# Altium schematic sheet hcsr04.SchDoc
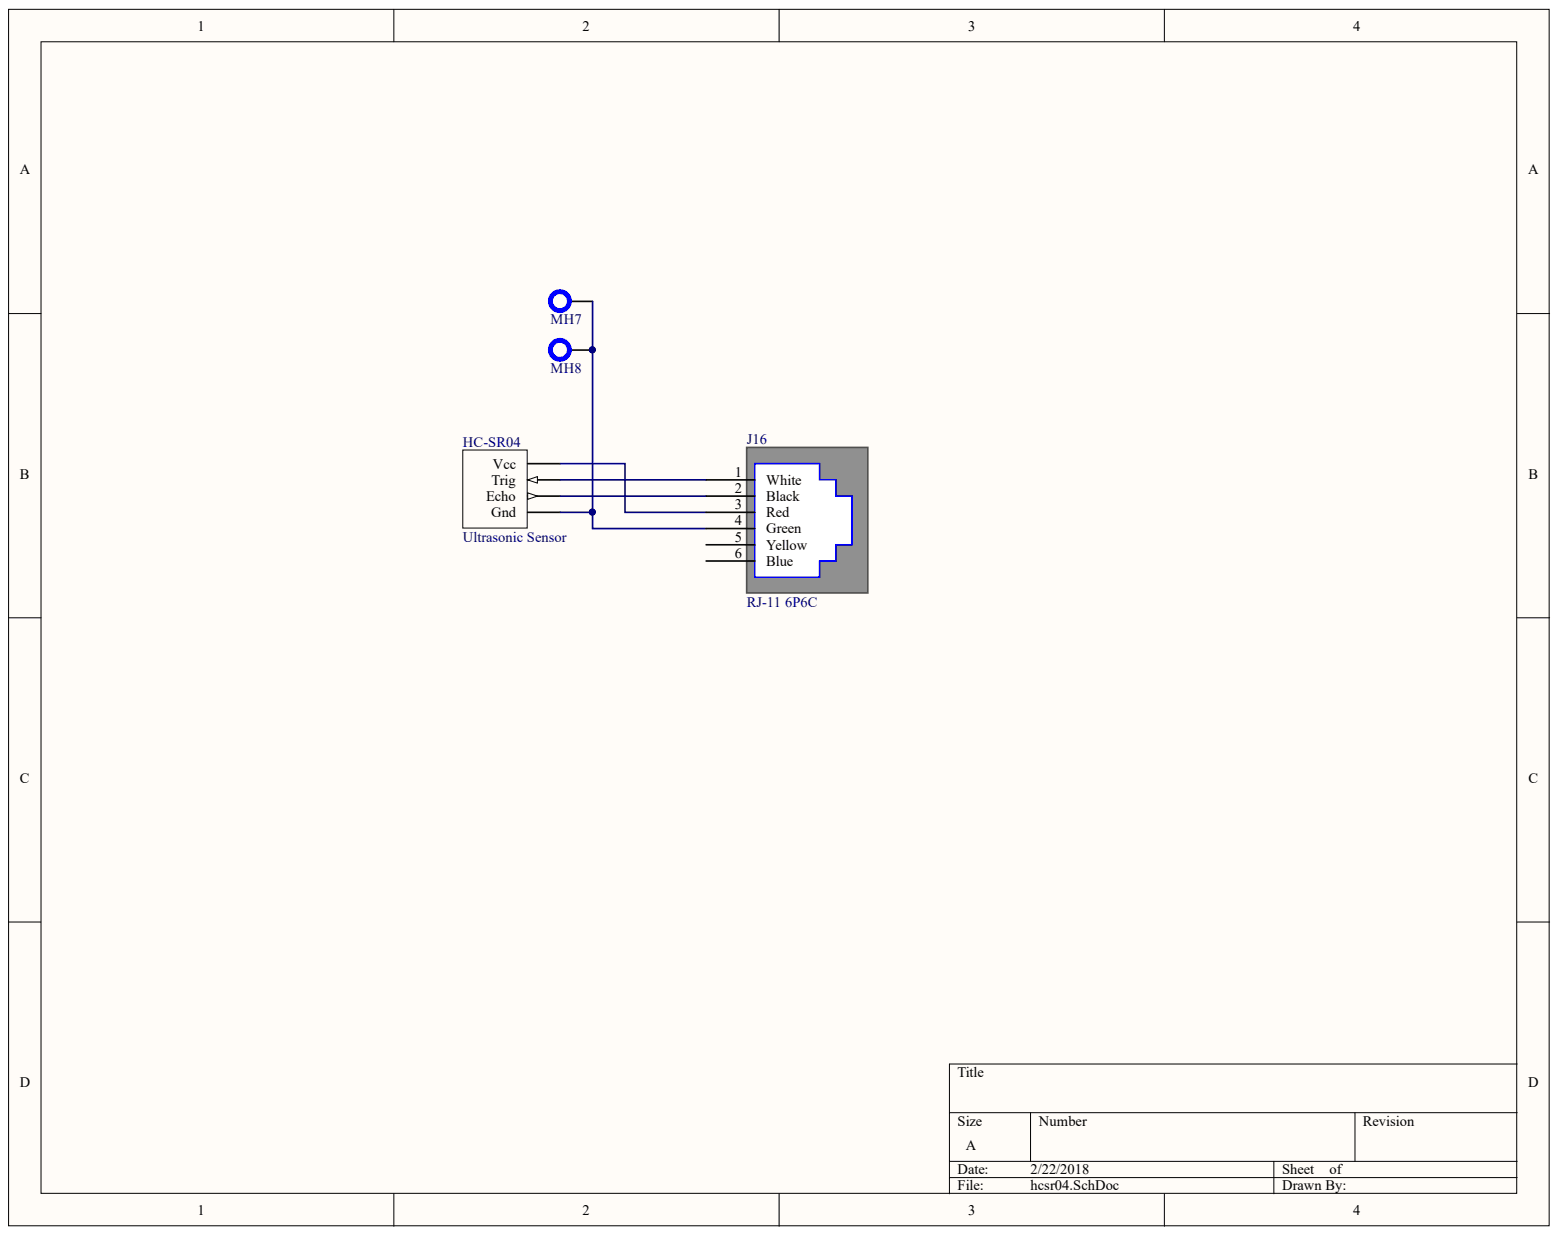
[diagram p0: hcsr04.SchDoc]

## Parts
HC-SR04  Ultrasonic Sensor  [PCB-mx1y8omaqth4jr3a8ds5-1]
J16  RJ-11 6P6C  [PCB-dhj0y0hoiu0232y63q4o-1]
MH7  MountHole  [PCB-d0jqlyxt4wqhvgbnry4h-1]  (value not drawn)
MH8  MountHole  [PCB-d0jqlyxt4wqhvgbnry4h-1]  (value not drawn)

## Nets
NetHC-SR04_1: HC-SR04.1(Vcc) J16.3(Red)
NetHC-SR04_2: HC-SR04.2(Trig) J16.1(White)
NetHC-SR04_3: HC-SR04.3(Echo) J16.2(Black)
NetHC-SR04_4: HC-SR04.4(Gnd) J16.4(Green) MH7.1 MH8.1

Not connected: J16 5(Yellow) 6(Blue)

## Library data of the parts
HC-SR04 = CMP-75f1bf27582daa36-6: Ultrasonic Sensor Module; Component Kind: Standard; Library Name: CommunityVault; OctopartID: 75f1bf27582daa36
J16 = CMP-33ecfa0a2b0dec2b-2: Conn RJ-11 F 6 POS 1.02mm Solder RA Thru-Hole 6 Terminal 1 Port; Manufacturer Part Number: PT-J623SS-66GR; Component Kind: Standard; Library Name: CommunityVault; Lifecycle Status: Not Listed by Manufacturer; OctopartID: 33ecfa0a2b0dec2b; RoHS: Compliant
MH7 MH8 = CMP-eo2hwbm1pte8m6tn8ao3-1: M3 mounthole with space for 5mm dia stands; Component Kind: Standard; CustomComponentID: eo2hwbm1pte8m6tn8ao3; Library Name: CommunityVault
